# Altium schematic sheet LEDS.SchDoc
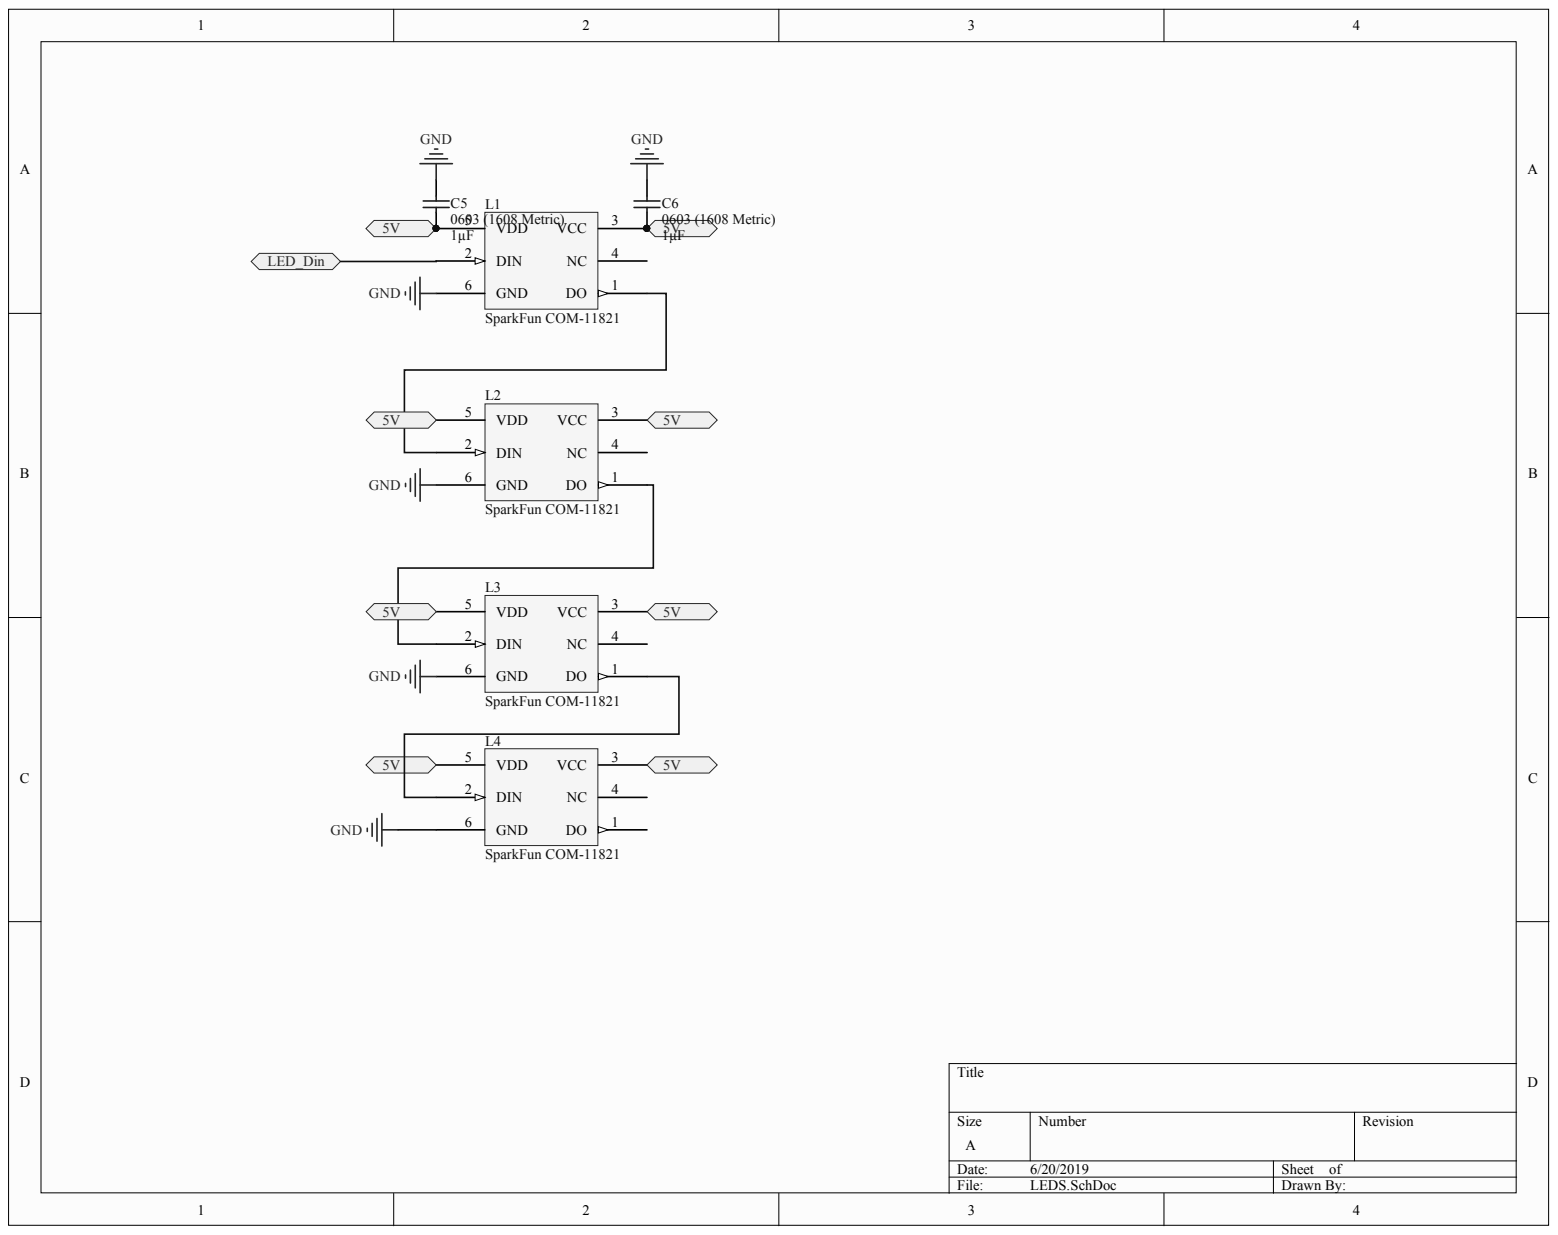
[diagram p0: LEDS.SchDoc]

## Parts
C5  Capacitance: 1µF  [PCB-lkmleyt60a11wkc2qm7q-1]  (hidden comment: Samsung CL10A105KP8NNNC)
C6  Capacitance: 1µF  [PCB-lkmleyt60a11wkc2qm7q-1]  (hidden comment: Samsung CL10A105KP8NNNC)
L1  SparkFun COM-11821  [PCB-1ur4ffa4apwrigk38oa4-1]
L2  SparkFun COM-11821  [PCB-1ur4ffa4apwrigk38oa4-1]
L3  SparkFun COM-11821  [PCB-1ur4ffa4apwrigk38oa4-1]
L4  SparkFun COM-11821  [PCB-1ur4ffa4apwrigk38oa4-1]

## Ports
5V, LED_DIN

## Nets
GND: C5.2 C6.2 L1.6(GND) L2.6(GND) L3.6(GND) L4.6(GND)
LED_DIN: L1.2(DIN) ; port LED_DIN
5V: C5.1 C6.1 L1.3(VCC) L1.5(VDD) L2.3(VCC) L2.5(VDD) L3.3(VCC) L3.5(VDD) L4.3(VCC) L4.5(VDD) ; port 5V
NetL1_1: L1.1(DO) L2.2(DIN)
NetL2_1: L2.1(DO) L3.2(DIN)
NetL3_1: L3.1(DO) L4.2(DIN)

Not connected: L1 4(NC); L2 4(NC); L3 4(NC); L4 1(DO) 4(NC)

## Library data of the parts
C5 C6 = CMP-1956d172a695c691-3: CL10 Series 1 uF 10 V ±10 % Tolerance X5R SMT Multilayer Ceramic Capacitor; Manufacturer: Samsung Electro-Mechanics; Vendor Part Number: 1276-1182-1-ND; Tolerance: ±10%; Vendor: Digikey; Voltage - Rated: 10V; Applications: General Purpose; Component Kind: Standard; Cost: 0.10; HelpURL: http://www.samsungsem.com/kr/support/product-search/mlcc/__icsFiles/afieldfile/2016/08/18/S_CL10A105KP8NNNC.pdf; Library Name: CommunityVault; Manufacturer PArt Number: CL10A105KP8NNNC; Mounting Type: Surface Mount, MLCC; Operating Temperature: -55°C ~ 85°C; Package / Case: 0603 (1608 Metric); Series: CL; Size / Dimension: 0.063" L x 0.032" W (1.60mm x 0.80mm); Temperature Coefficient: X5R; Thickness (Max): 0.035" (0.90mm)
L1-L4 = CMP-ba0777713b8065c0-3: Led - Smd Rgb (Ws2812); Component Kind: Standard; Library Name: CommunityVault; OctopartID: ba0777713b8065c0
